# Altium schematic sheet lv_signal_link.SchDoc(lv_signal_link)
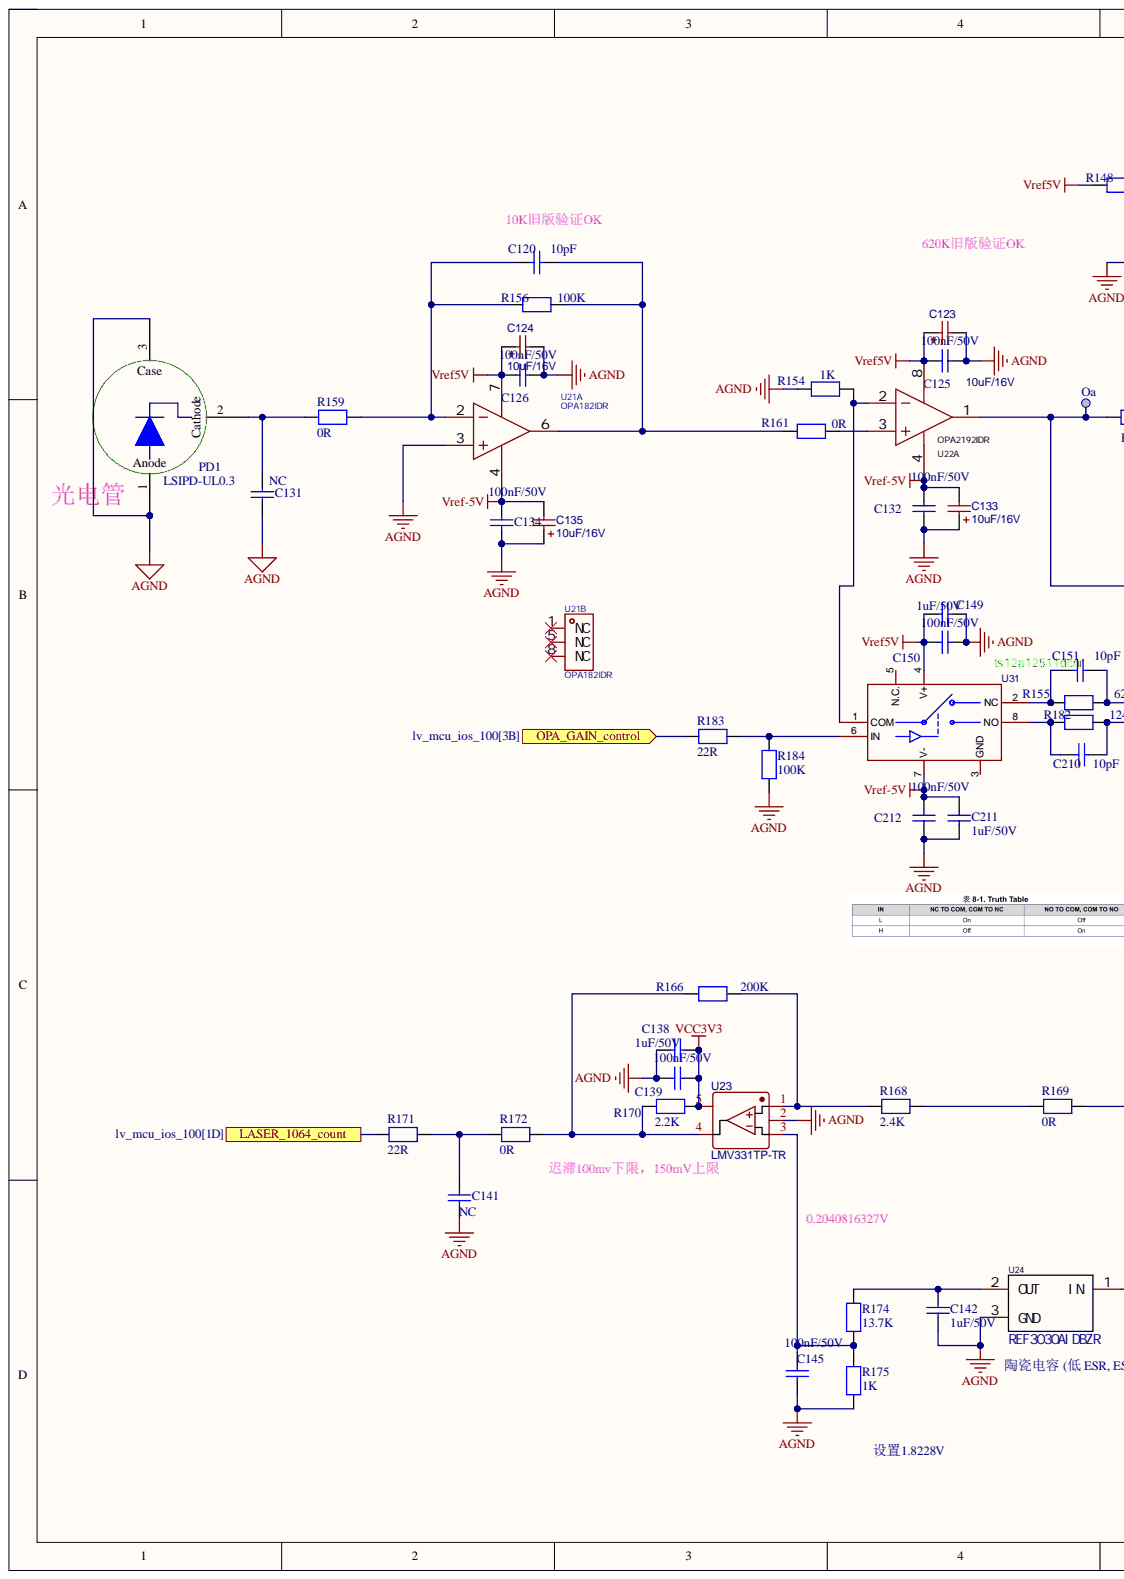
[diagram p0: lv_signal_link.SchDoc, left]
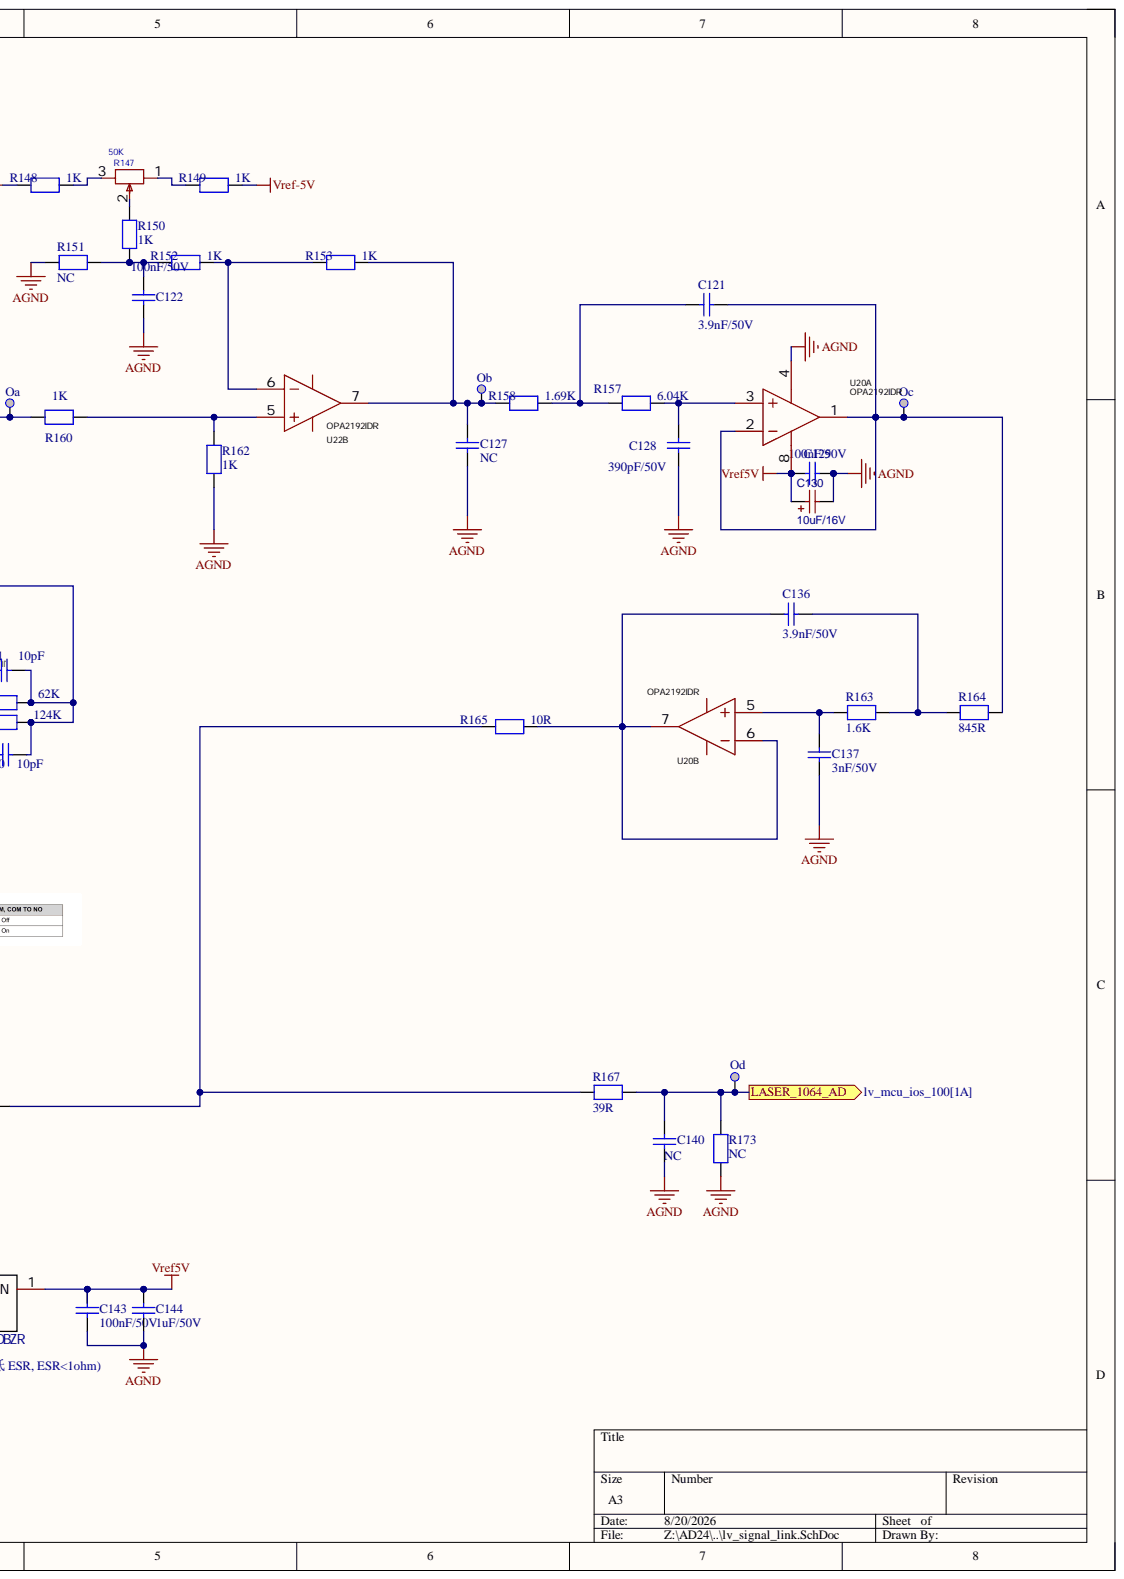
[diagram p1: lv_signal_link.SchDoc, right]

## Parts
C120  10pF  [C0603]
C121  3.9nF/50V  [C0603]
C122  100nF/50V  [C0402]
C123  10uF/16V  [CAP-SMD_L3.2-W1.6-RD-C7171]  (value not drawn)
C124  10uF/16V  [CAP-SMD_L3.2-W1.6-RD-C7171]
C125  100nF/50V  [C0402]
C126  100nF/50V  [C0402]
C127  NC  [C0603]
C128  390pF/50V  [C0603]
C129  100nF/50V  [C0402]
C130  10uF/16V  [CAP-SMD_L3.2-W1.6-RD-C7171]
C131  NC  [C0402]
C132  100nF/50V  [C0402]
C133  10uF/16V  [CAP-SMD_L3.2-W1.6-RD-C7171]
C134  100nF/50V  [C0402]
C135  10uF/16V  [CAP-SMD_L3.2-W1.6-RD-C7171]
C136  3.9nF/50V  [C0603]
C137  3nF/50V  [C0603]
C138  1uF/50V  [C0603]
C139  100nF/50V  [C0402]
C140  NC  [C0603]
C141  NC  [C0603]
C142  1uF/50V  [C0603]
C143  100nF/50V  [C0402]
C144  1uF/50V  [C0603]
C145  100nF/50V  [C0402]
C149  1uF/50V  [C0603]
C150  100nF/50V  [C0402]
C151  10pF  [C0603]
C210  10pF  [C0603]
C211  1uF/50V  [C0603]
C212  100nF/50V  [C0402]
PD1  LSIPD-UL0.3  [photodiode1064]
R147  50K  [RES-ADJ-SMD_3224W]
R148  1K  [R0603]
R149  1K  [R0603]
R150  1K  [R0603]
R151  NC  [R0603]
R152  1K  [R0603]
R153  1K  [R0603]
R154  1K  [R0603]
R155  62K  [R0603]
R156  100K  [R0603]
R157  6.04K  [R0603]
R158  1.69K  [R0603]
R159  0R  [R0402]
R160  1K  [R0603]
R161  0R  [R0603]
R162  1K  [R0603]
R163  1.6K  [R0603]
R164  845R  [R0603]
R165  10R  [R0603]
R166  200K  [R0402]
R167  39R  [R0402]
R168  2.4K  [R0402]
R169  0R  [R0603]
R170  2.2K  [R0402]
R171  22R  [R0402]
R172  0R  [R0402]
R173  NC  [R0402]
R174  13.7K  [R0402]
R175  1K  [R0402]
R182  124K  [R0603]
R183  22R  [R0402]
R184  100K  [R0402]
TP20  Ob  [TP_SMD_1MM]  (no BOM)
TP21  Oa  [TP_SMD_1MM]  (no BOM)
TP22  Oc  [TP_SMD_1MM]  (no BOM)
TP23  Od  [TP_SMD_1MM]  (no BOM)
U20  OPA2192IDR  [SOIC-8_L4.9-W3.9-P1.27-LS6.0-BL]  (parts A,B)
U21  OPA182IDR  [SOIC-8_L4.9-W3.9-P1.27-LS6.0-BL]  (parts A,B)
U22  OPA2192IDR  [SOIC-8_L4.9-W3.9-P1.27-LS6.0-BL]  (parts A,B)
U23  LMV331TP-TR  [SOT-23-5_L3.0-W1.7-P0.95-LS2.8-BR]
U24  REF3030AIDBZR  [SOT-23-3_L2.9-W1.6-P1.90-LS2.8-BR]
U31  ts12a12511dcnr  [SOT-23-8_L3.0-W1.7-P0.65-LS2.8-BR]

## Ports
LASER_1064_AD, LASER_1064_count, OPA_GAIN_control

## Nets
AGND: C122.2 C123.2 C124.2 C125.2 C126.2 C127.1 C128.1 C129.2 C130.2 C131.2 C132.2 C133.1 C134.2 C135.1 C137.1 C138.1 C139.2 C140.1 C141.1 C142.1 C143.1 C144.1 C145.2 C149.2 C150.2 C211.1 C212.2 PD1.1 PD1.3 R151.1 R154.2 R162.2 R173.1 R175.1 R184.1 U20.4 U21.3 U23.2 U24.3
VCC3V3: C138.2 C139.1 R170.2 U23.5
Vref5V: C123.1 C124.1 C125.1 C126.1 C129.1 C130.1 C143.2 C144.2 C149.1 C150.1 R148.2 U20.8 U21.7 U22.8 U24.1 U31.4(V+)
Vref-5V: C132.1 C133.2 C134.1 C135.2 C211.2 C212.1 R149.1 U21.4 U22.4 U31.7(V-)
LASER_1064_AD: C140.2 R167.2 R173.2 TP23.1 ; port LASER_1064_AD
LASER_1064_count: R171.1 ; port LASER_1064_count
OPA_GAIN_control: R183.2 ; port OPA_GAIN_control
NetC120_1: C120.1 R156.2 R159.2 U21.2
NetC120_2: C120.2 R156.1 R161.2 U21.6
NetC121_1: C121.1 R164.2 TP22.1 U20.1 U20.2
NetC121_2: C121.2 R157.2 R158.1
NetC122_1: C122.1 R150.2 R151.2 R152.2
NetC127_2: C127.2 R153.1 R158.2 TP20.1 U22.7
NetC128_2: C128.2 R157.1 U20.3
NetC131_1: C131.1 PD1.2 R159.1
NetC136_1: C136.1 R165.1 U20.6 U20.7
NetC136_2: C136.2 R163.2 R164.1
NetC137_2: C137.2 R163.1 U20.5
NetC141_2: C141.2 R171.2 R172.1
NetC142_2: C142.2 R174.2 U24.2
NetC145_1: C145.1 R174.1 R175.2 U23.3
NetC151_1: C151.1 R155.2 U31.2(NC)
NetC151_2: C151.2 C210.2 R155.1 R160.2 R182.1 TP21.1 U22.1
NetC210_1: C210.1 R182.2 U31.8(NO)
NetR147_1: R147.1 R149.2
NetR147_2: R147.2 R150.1
NetR147_3: R147.3 R148.1
NetR152_1: R152.1 R153.2 U22.6
NetR154_1: R154.1 U22.2 U31.1(COM)
NetR160_1: R160.1 R162.1 U22.5
NetR161_1: R161.1 U22.3
NetR165_2: R165.2 R167.1 R169.2
NetR166_1: R166.1 R168.2 U23.1
NetR166_2: R166.2 R170.1 R172.2 U23.4
NetR168_1: R168.1 R169.1
NetR183_1: R183.1 R184.2 U31.6(IN)

Not connected: U21 1(NC) 5(NC) 8(NC); U31 3(GND) 5(N.C.)

## Library data of the parts
C120 C151 C210 = Capacitor_1: 16V, X5R, 10%; Internal_Part_Number: MCD-00009; Manufacturer: YAGEO; Manufacturer_Part_Number: CC0603BRNPO9BN1R0; Component Kind: Standard; ComponentLink1Description: Yageo_Capacitor_X5R-series.pdf; ComponentLink1URL: http://tunmcdsvn.tun.st.com/Component_Datasheet_Library/Trunk/Capacitors/Yageo_Multilayer_capacitor_X5R_series_100pF_to_100uF; Library Name: HiPin_Library_AD.IntLib; Package: C0603
C121 = Capacitor_1: 50V, COG, 10%; Internal_Part_Number: MCD-00009; Manufacturer: muRata; Manufacturer_Part_Number: GRM1885C1H392JA01D; Component Kind: Standard; ComponentLink1Description: Yageo_Capacitor_X5R-series.pdf; ComponentLink1URL: http://tunmcdsvn.tun.st.com/Component_Datasheet_Library/Trunk/Capacitors/Yageo_Multilayer_capacitor_X5R_series_100pF_to_100uF; Library Name: HiPin_Library_AD.IntLib; Package: C0603
C122 C125 C126 C129 C132 C134 C139 C145 C150 C212 = Capacitor: 50V, 10%, X7R; Internal_Part_Number: MCD-00003; Manufacturer: muRata; Manufacturer_Part_Number: GRM155R71H104KE14D; Value: 100nF; Component Kind: Standard; ComponentLink1Description: Datasheet; ComponentLink1URL: http://tunmcdsvn.tun.st.com/Component_Datasheet_Library/Trunk/Capacitors/Yageo_Capacitor_NP0_16V-to-50V; Library Name: HiPin_Library_AD.IntLib; Package: C0402
C123 C124 C130 C133 C135 = 10uF: TA 0.8ohm,10%; Manufacturer: AVX; Manufacturer_Part_Number: TAJA106K016RNJ; Component Kind: Standard; Library Name: HiPin_Library_AD.IntLib
C127 = Capacitor_1: 16V, X5R, 10%; Internal_Part_Number: MCD-00009; Manufacturer: muRata; Manufacturer_Part_Number: GRM21BR61C106KE15L; Component Kind: Standard; ComponentLink1Description: Yageo_Capacitor_X5R-series.pdf; ComponentLink1URL: http://tunmcdsvn.tun.st.com/Component_Datasheet_Library/Trunk/Capacitors/Yageo_Multilayer_capacitor_X5R_series_100pF_to_100uF; Library Name: HiPin_Library_AD.IntLib; Package: C0603
C128 = Capacitor_1: 50V, C0G, 5%; Manufacturer: muRata; Manufacturer_Part_Number: GRM1885C1H391JA01D; Component Kind: Standard; Library Name: HiPin_Library_AD.IntLib; Package: C0603
C131 = Capacitor: 50V, 10%, X7R; Internal_Part_Number: MCD-00003; Manufacturer: muRata; Component Kind: Standard; ComponentLink1Description: Datasheet; ComponentLink1URL: http://tunmcdsvn.tun.st.com/Component_Datasheet_Library/Trunk/Capacitors/Yageo_Capacitor_NP0_16V-to-50V; Library Name: HiPin_Library_AD.IntLib; Package: C0402
C136 = Capacitor_1: 50V, C0G, 5%; Manufacturer: muRata; Manufacturer_Part_Number: GRM1885C1H392JA01D; Component Kind: Standard; Library Name: HiPin_Library_AD.IntLib
C137 = Capacitor_1: 50V,C0G, 5%; Manufacturer: muRata; Manufacturer_Part_Number: GRM1885C1H302JA01D; Component Kind: Standard; Library Name: HiPin_Library_AD.IntLib; Package: C0603
C138 C149 C211 = MCD-00010: 50V, X5R; Manufacturer: YAGEO; Manufacturer_Part_Number: CC0603KRX5R7BB105; Part_Type: Capacitor; Database Table Name: MCD_PARTS$; Initial_Board_Reference: MB1274; Provided_By: Subcon; Component Kind: Standard; ComponentLink1Description: Yageo_Capacitor_X5R-series.pdf; ComponentLink1URL: http://tunmcdsvn.tun.st.com/Component_Datasheet_Library/Trunk/Capacitors/Yageo_Multilayer_capacitor_X5R_series_100pF_to_100uF; ECCN_EU: n/a; ECCN_US: n/a; Internal_Part_Number: MCD-00010; Library Name: MCD_PARTS_database.DbLib; Package: C0603; Status: Released
C140 C141 = Capacitor_1: 50V, X7R, 10%; Manufacturer: YAGEO; Manufacturer_Part_Number: CC0603KRX7R9BB474; Component Kind: Standard; Library Name: HiPin_Library_AD.IntLib
C142 C144 = MCD-00010: X5R, 10%; Manufacturer: YAGEO; Manufacturer_Part_Number: CC0603KRX5R7BB105; Component Kind: Standard; Library Name: MB1397.IntLib
  C142: ComponentLink1Description: Datasheet; ComponentLink1URL: http://tunmcdsvn.tun.st.com/Component_Datasheet_Library/Trunk/Capacitors/Yageo_Multilayer_capacitor_X5R_series_100pF_to_100uF
C143 = MCD-00006: X7R, 10%; Alternate_Manufacturer: MURATA; Alternate_Manufacturer_Part_Number: GCM155R71C104KA55D; Manufacturer_Part_Number: GRM155R71H104KE14D; Initial_Board_Reference: MB1274; Item Type: Item; Path: ws/MCD_ApplicationBoards/Lists/MCDPARTSdatabase; Component Kind: Standard; ComponentLink1Description: Datasheet; ComponentLink1URL: http://tunmcdsvn.tun.st.com/Component_Datasheet_Library/Trunk/Capacitors/Yageo_Multilayer_Capacitor_X7R_series_100pF_to_22uF; Library Name: MB1397.IntLib; Manufacturer: MURATA
PD1 = PhotoDiode: 6G; Component Kind: Standard; Library Name: HiPin_Library_AD.IntLib
R147 = 3224W-1-103E: 可调电阻; Manufacturer: BOURNS; Manufacturer Part: 3224W-1-503E; Temperature Coefficient: ±100ppm/℃; Component Kind: Standard; Datasheet: https://atta.szlcsc.com/upload/public/pdf/source/20160830/1472551532733.pdf; Library Name: HiPin_Library_AD.IntLib; PCB3D: RES-ADJ-SMD_3224W
R148-R158 R160-R165 R167 R169 R171 R182 = RES: 1%; Component Kind: Standard; Library Name: HiPin_Library_AD.IntLib
R159 R166 R168 R170 R172 R173 R183 R184 = RES: 1%; Value: 10K; Component Kind: Standard; Library Name: HiPin_Library_AD.IntLib
R174 R175 = RES: Resistor; Component Kind: Standard; Library Name: HiPin_Library_AD.IntLib
TP20-TP23 = MCD-00074: Test Point 1mm; Part_Type: Mechanical; Database Table Name: MCD_PARTS$; ComponentLink1Description: Datasheet; ComponentLink1URL: http://na; ECCN_EU: n/a; ECCN_US: n/a; Internal_Part_Number: MCD-00074; Library Name: MCD_PARTS_database.DbLib; Manufacturer: n/a; Manufacturer_Part_Number: n/a; Provided_By: n/a; Status: Released
U20 U22 = OPA2192IDR: 运放; Manufacturer: TI(德州仪器); Add into BOM: yes; Current - Input Bias(Ib): 5pA; Gain Bandwidth Product(GBP): 10MHz; Number of Circuits: 双路; Output Current: 65mA; Rail to Rail: 轨到轨输入输出; Slew Rate(SR): 20V/us; Supply Current: 1mA; -3db Bandwidth(G=1): -; Common Mode Rejection Ratio (CMRR): -; Component Kind: Standard; Convert to PCB: yes; Datasheet: https://www.ti.com/cn/lit/gpn/opa2192; Device: OPA2192IDR; Input Offset Voltage Drift (Vos TC): -; JLCPCB Part Class: Extended Part; LCSC Part Name: OPA2192IDR; Library Name: HiPin_Library_AD.IntLib; Manufacturer Part: OPA2192IDR; Operating Temperature: -40℃~+125℃; Output Type: -; PCB3D: SOIC-8_L4.9-W3.9-H1.7-LS6.0-P1.27; Supplier: LCSC; Supplier Footprint: SOIC-8; Supplier Part: C110074; Supply Voltage: -; Symbol: OPA2192IDR; Voltage - Input Offset(Vos): -
U21 = OPA182IDR: FET输入运放，但路，145Mhz， 1pA; Manufacturer: ADI; Manufacturer Part: AD8065ARZ; Gain Bandwidth Product(GBP): 145MHz; Number of Circuits: 单路; Component Kind: Standard; Library Name: HiPin_Library_AD.IntLib; Operating Temperature: -40℃~+85℃; PCB3D: SOIC-8_L4.9-W3.9-H1.7-LS6.0-P1.27; Supplier Footprint: SOIC-8
U23 = LMV331TP-TR: 比较器; BOM_Manufacturer: 3PEAK; Contributor: LCSC; BOM_JLCPCB Part Class: Extended Part; BOM_Manufacturer Part: LMV331TP-TR; BOM_Supplier: LCSC; BOM_Supplier Part: C108095; Component Kind: Standard; Library Name: HiPin_Library_AD.IntLib; spicePre: U; spiceSymbolName: LMV331TP-TR
U24 = REF3030AIDBZR: 3.0V参考电源; Manufacturer: TI(德州仪器); Add into BOM: yes; Component Kind: Standard; Convert to PCB: yes; Datasheet: https://atta.szlcsc.com/upload/public/pdf/source/20141125/1457707248562.pdf; Device: REF3030AIDBZR; JLCPCB Part Class: Extended Part; LCSC Part Name: REF3030AIDBZR; Library Name: HiPin_Library_AD.IntLib; Manufacturer Part: REF3030AIDBZR; Name: REF3030AIDBZR; PCB3D: SOT-23-3L_L2.9-W1.6-H1.1-LS2.8-P0.95; Supplier: LCSC; Supplier Footprint: SOT-23; Supplier Part: C38423; Symbol: REF3030AIDBZR
U31 = ts12a12511dcnr: 开关电路:2:1;通道数:1;导通电阻(Ron):5Ω;; Manufacturer: TI(德州仪器); AddInBom: yes; Bandwidth: 93MHz; ModelId: 4b2aaf679ac349bebfd5ff04ad2c8b93; ModelName: SOT-23-8_L2.9-W1.6-H1.5-LS2.8-P0.65; ModelTransform: 110.73,114.173,0,180,0,0,0,-0.0005,0; Number of Channels: 1; Ron: 5Ω; Switch Circuit: 2:1; Turn-off time: 41ns; Turn-on time: 80ns; Voltage - Supply: 2.7V~12V；-6V~6V; Component Kind: Standard; Con: -; ConvertToPcb: yes; Datasheet: https://www.ti.com/cn/lit/gpn/ts12a12511; Features: 双向模拟通道；无毛刺切换; FootprintName: SOT-23-8_L3.0-W1.7-P0.65-LS2.8-BR; JLCPCB Part Class: Extended Part; LCSC Part Name: TS12A12511 单通道 SPDT 模拟开关; Library Name: HiPin_Library_AD.IntLib; ManufacturerPart: TS12A12511DCNR; Operating Temperature: -40℃~+85℃; Propagation Delay (tpd): -; Supplier: LCSC; Supplier Footprint: SOT-23-8; SupplierPart: C111869; Symbol: TS12A12511DCNR
